# Altium schematic sheet Gate Driver Interface.SchDoc("Gate Driver Interface")
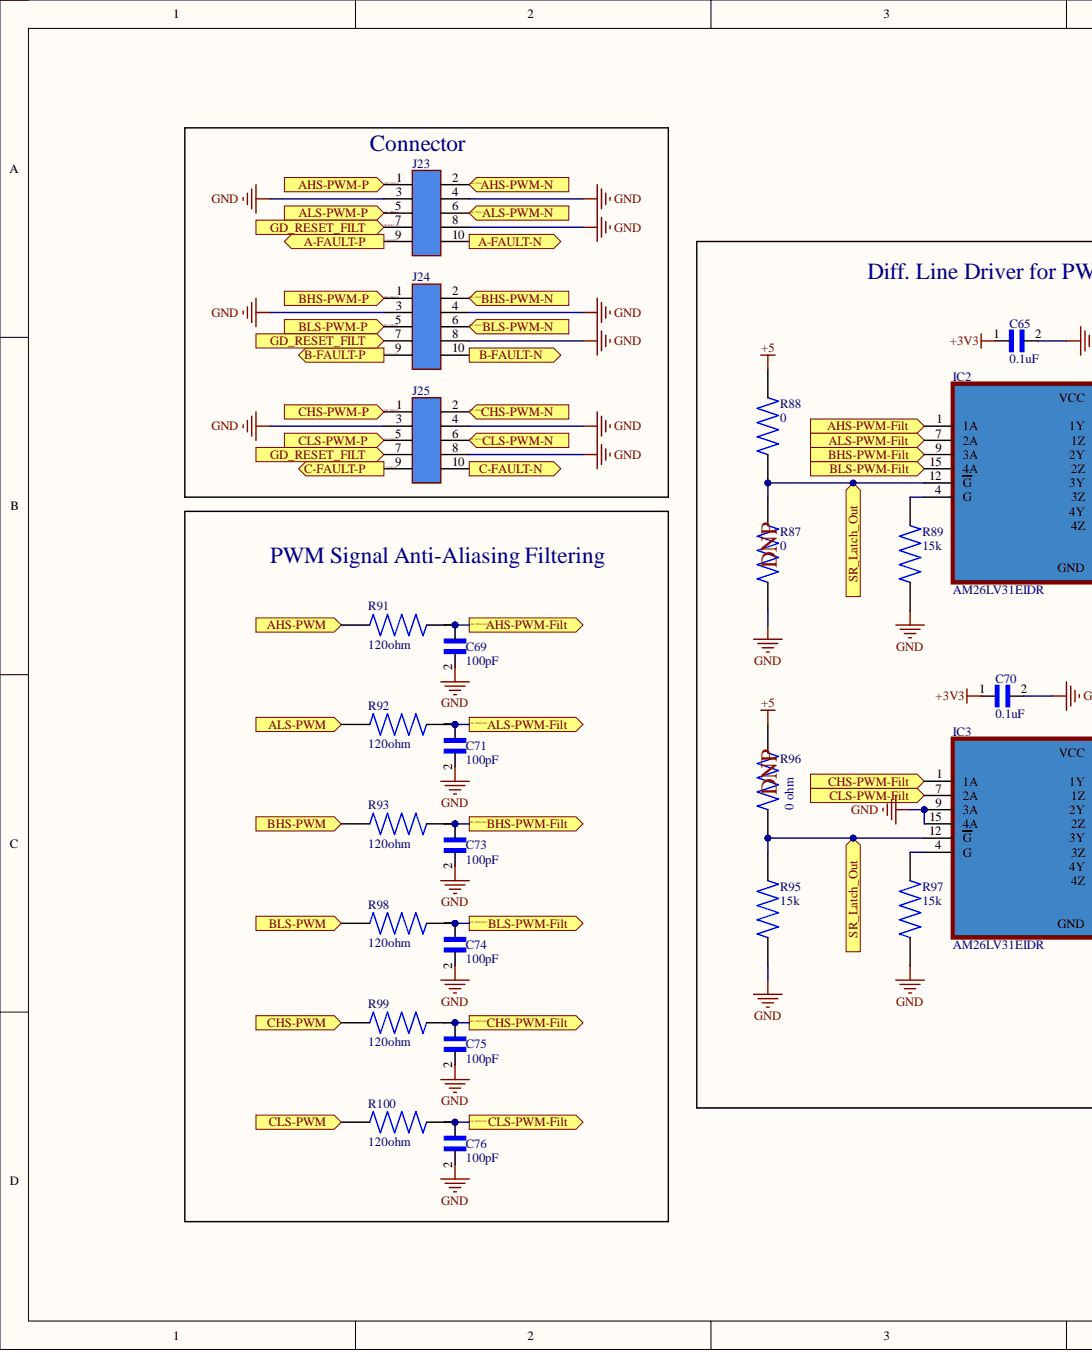
[diagram p0: Gate Driver Interface.SchDoc, left]
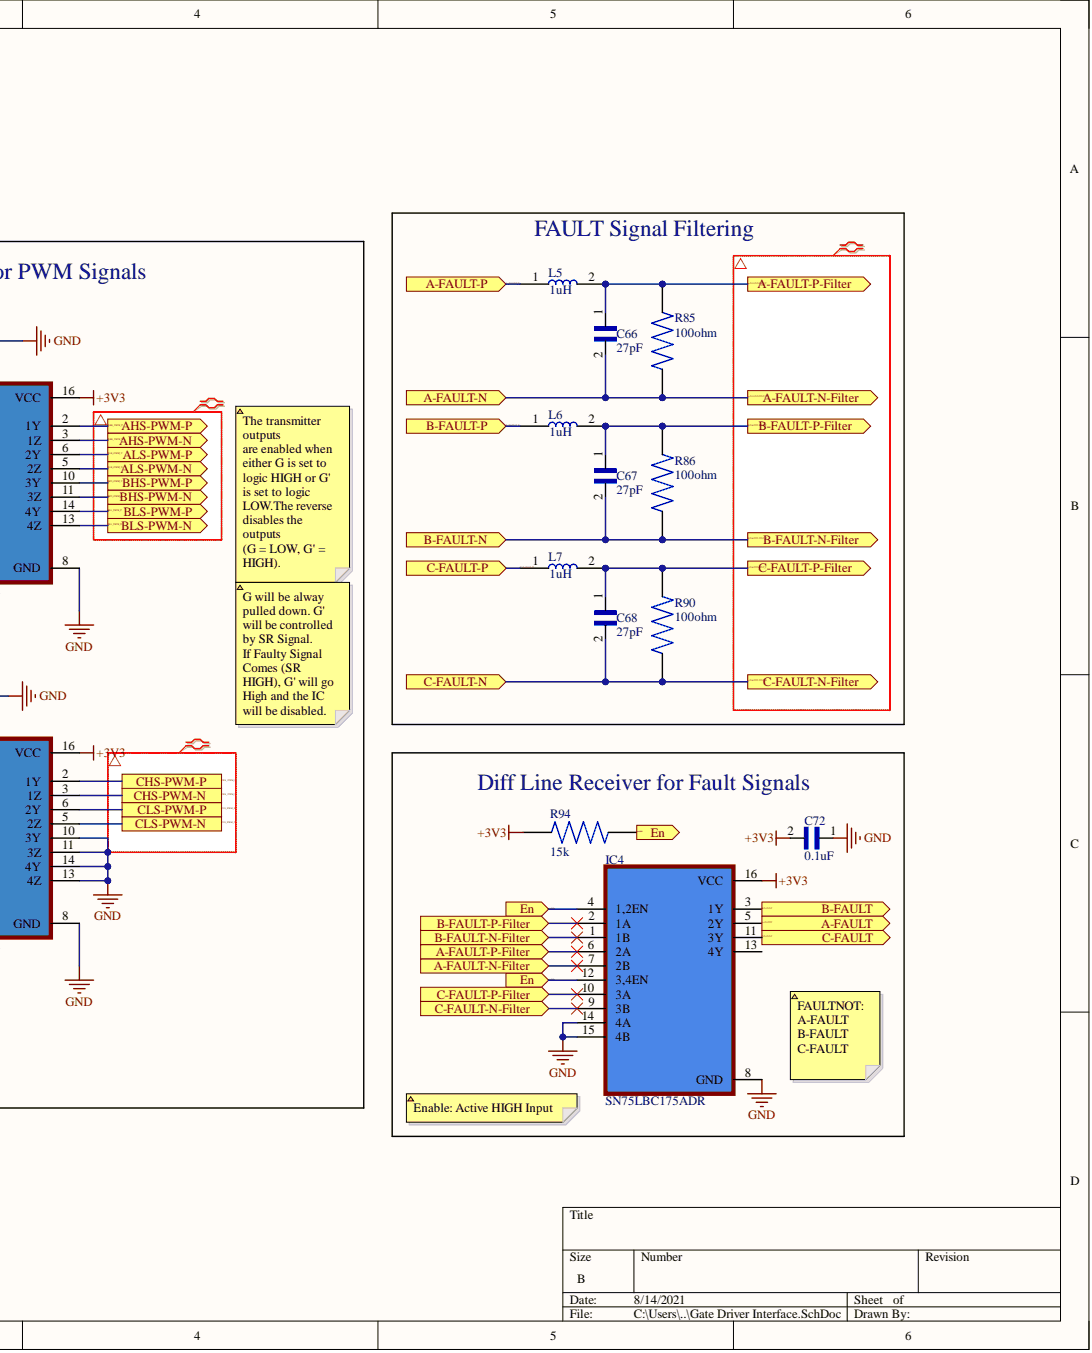
[diagram p1: Gate Driver Interface.SchDoc, right]

## Parts
C65  0.1uF  [CAP 0805(2012 metric)]
C66  27pF  [CAP 0805(2012 metric)]
C67  27pF  [CAP 0805(2012 metric)]
C68  27pF  [CAP 0805(2012 metric)]
C69  100pF  [CAP 0805(2012 metric)]
C70  0.1uF  [CAP 0805(2012 metric)]
C71  100pF  [CAP 0805(2012 metric)]
C72  0.1uF  [CAP 0805(2012 metric)]
C73  100pF  [CAP 0805(2012 metric)]
C74  100pF  [CAP 0805(2012 metric)]
C75  100pF  [CAP 0805(2012 metric)]
C76  100pF  [CAP 0805(2012 metric)]
IC2  AM26LV31EIDR  [SOIC127P600X175-16N]
IC3  AM26LV31EIDR  [SOIC127P600X175-16N]
IC4  SN75LBC175ADR  [SN75LBC175ADR]
J23  2ROW10POS  [2ROW10POS]  (value not drawn)
J24  2ROW10POS  [2ROW10POS]  (value not drawn)
J25  2ROW10POS  [2ROW10POS]  (value not drawn)
L5  1uH  [IND 0805]
L6  1uH  [IND 0805]
L7  1uH  [IND 0805]
R85  100ohm  [RES 0805(2012 metric)]
R86  100ohm  [RES 0805(2012 metric)]
R87  0  [RES 0805(2012 metric)]
R88  0  [RES 0805(2012 metric)]
R89  15k  [RES 0805(2012 metric)]
R90  100ohm  [RES 0805(2012 metric)]
R91  120ohm  [RES 0805(2012 metric)]
R92  120ohm  [RES 0805(2012 metric)]
R93  120ohm  [RES 0805(2012 metric)]
R94  15k  [RES 0805(2012 metric)]
R95  15k  [RES 0805(2012 metric)]
R96  0 ohm  [RES 0805(2012 metric)]
R97  15k  [RES 0805(2012 metric)]
R98  120ohm  [RES 0805(2012 metric)]
R99  120ohm  [RES 0805(2012 metric)]
R100  120ohm  [RES 0805(2012 metric)]

## Ports
A-FAULT, A-FAULT-N-Filter_N, A-FAULT-N_N, A-FAULT-P-Filter_P, A-FAULT-P_P, AHS-PWM, AHS-PWM-Filt, AHS-PWM-N_N, AHS-PWM-P_P, ALS-PWM, ALS-PWM-Filt, ALS-PWM-N_N, ALS-PWM-P_P, B-FAULT, B-FAULT-N-Filter_N, B-FAULT-N_N, B-FAULT-P-Filter_P, B-FAULT-P_P, BHS-PWM, BHS-PWM-Filt, BHS-PWM-N_N, BHS-PWM-P_P, BLS-PWM, BLS-PWM-Filt, BLS-PWM-N_N, BLS-PWM-P_P, C-FAULT, C-FAULT-N-Filter_N, C-FAULT-N_N, C-FAULT-P-Filter_P, C-FAULT-P_P, CHS-PWM, CHS-PWM-Filt, CHS-PWM-N_N, CHS-PWM-P_P, CLS-PWM, CLS-PWM-Filt, CLS-PWM-N_N, CLS-PWM-P_P, En, GD_RESET_FILT, SR_Latch_Out

## Nets
+3V3: C65.1 C70.1 C72.2 IC2.16(VCC) IC3.16(VCC) IC4.16(VCC) R94.2
+5: R88.2 R96.2
GND: C65.2 C69.2 C70.2 C71.2 C72.1 C73.2 C74.2 C75.2 C76.2 IC2.8(GND) IC3.8(GND) IC3.9(3A) IC3.10(3Y) IC3.11(3Z) IC3.13(4Z) IC3.14(4Y) IC3.15(4A) IC4.8(GND) IC4.14(4A) IC4.15(4B) J23.3 J23.4 J23.8 J24.3 J24.4 J24.8 J25.3 J25.4 J25.8 R87.1 R89.1 R95.1 R97.1
A-FAULT: IC4.5(2Y) ; port A-FAULT
A-FAULT-FILT_N: C66.2 IC4.7(2B) J23.10 R85.1
A-FAULT-FILT_P: C66.1 IC4.6(2A) L5.2 R85.2
A_FAULT_P: J23.9 L5.1
AHS-PWM: R91.1 ; port AHS-PWM
AHS_PWM_FILT: C69.1 IC2.1(1A) R91.2 ; port AHS-PWM-Filt
AHS_PWM_N: IC2.3(1Z) J23.2
AHS_PWM_P: IC2.2(1Y) J23.1
ALS-PWM: R92.1 ; port ALS-PWM
ALS_PWM_FILT: C71.1 IC2.7(2A) R92.2 ; port ALS-PWM-Filt
ALS_PWM_N: IC2.5(2Z) J23.6
ALS_PWM_P: IC2.6(2Y) J23.5
B-FAULT: IC4.3(1Y) ; port B-FAULT
B-FAULT-FILT_N: C67.2 IC4.1(1B) J24.10 R86.1
B-FAULT-FILT_P: C67.1 IC4.2(1A) L6.2 R86.2
B_FAULT_P: J24.9 L6.1
BHS-PWM: R93.1 ; port BHS-PWM
BHS_PWM_FILT: C73.1 IC2.9(3A) R93.2 ; port BHS-PWM-Filt
BHS_PWM_N: IC2.11(3Z) J24.2
BHS_PWM_P: IC2.10(3Y) J24.1
BLS-PWM: R98.1 ; port BLS-PWM
BLS_PWM_FILT: C74.1 IC2.15(4A) R98.2 ; port BLS-PWM-Filt
BLS_PWM_N: IC2.13(4Z) J24.6
BLS_PWM_P: IC2.14(4Y) J24.5
C-FAULT: IC4.11(3Y) ; port C-FAULT
C-FAULT-FILT_N: C68.2 IC4.9(3B) J25.10 R90.1
C-FAULT-FILT_P: C68.1 IC4.10(3A) L7.2 R90.2
C_FAULT_P: J25.9 L7.1
CHS-PWM: R99.1 ; port CHS-PWM
CHS_PWM_FILT: C75.1 IC3.1(1A) R99.2 ; port CHS-PWM-Filt
CHS_PWM_N: IC3.3(1Z) J25.2
CHS_PWM_P: IC3.2(1Y) J25.1
CLS-PWM: R100.1 ; port CLS-PWM
CLS_PWM_FILT: C76.1 IC3.7(2A) R100.2 ; port CLS-PWM-Filt
CLS_PWM_N: IC3.5(2Z) J25.6
CLS_PWM_P: IC3.6(2Y) J25.5
enable: IC4.4(1,2EN) IC4.12(3,4EN) R94.1 ; port En
GD_RESET_FILT: J23.7 J24.7 J25.7 ; port GD_RESET_FILT
SR_Latch_Out: IC2.12(G) IC3.12(G) R87.2 R88.1 R95.2 R96.1 ; port SR_Latch_Out
NetIC2_4: IC2.4(G) R89.2
NetIC3_4: IC3.4(G) R97.2

Not connected: IC4 13(4Y)

## Library data of the parts
C65 C70 C72 = 0.1uF 25V 0805: 0.1µF ±10% 25V Ceramic Capacitor X7R 0805 (2012Metric); Component Kind: Standard; Library Name: 0.1uF 25V 0805.SchLib
C66-C68 = 27pF 50V: CL10 Series 27 pF 50 V ±5 % Tolerance; Component Kind: Standard; Library Name: 27pF 0805.SchLib
C69 C71 C73-C76 = 100pF 50V: 100pF ±5% 50V Ceramic Capacitor C0G, NP0 0805 (2012 Metric); Component Kind: Standard; Library Name: 100pF 0805 50V.SchLib
L5-L7 = 1uH 0805: 1µH Shielded Multilayer Inductor 1.15A 60mOhm 0805 (2012 Metric); Component Kind: Standard; Library Name: 1uH 0805.SchLib
R85 R86 R90 = 100ohm 0.1W: 100 Ohms ±1% 0.1W, 1/10W Chip Resistor 0805(2012 Metric) Moisture Resistant Thick Film; Component Kind: Standard; Library Name: 100ohm 0805.SchLib
R87-R89 R94 R95 R97 = 15k 0805: 15k 0805 0.1W; Component Kind: Standard; Library Name: 15k 0805 0.1W.SchLib
R91-R93 R98-R100 = 120ohm 0805: 120 Ohms ±5% 0.1W, 1/10W Chip Resistor 0805 (2012 Metric) Moisture Resistant Thick Film; Component Kind: Standard; Library Name: 120ohm 0805.SchLib
R96 = 0ohm 0805: 0 ohm 0805 res; Component Kind: Standard; Library Name: 0ohm 0805.SchLib
IC2 IC3 = AM26LV31EIDR: Component Kind: Standard; Library Name: AM26LV31EIDR.SchLib
IC4 = SN75LBC175ADR: Component Kind: Standard; Library Name: SN75LBC175ADRv2.SchLib
J23-J25 = 2ROW10POS: Component Kind: Standard; Library Name: 2ROW10POS.SchLib
